# Altium schematic sheet DE-020224_01.SchDoc(DE-020224_01)
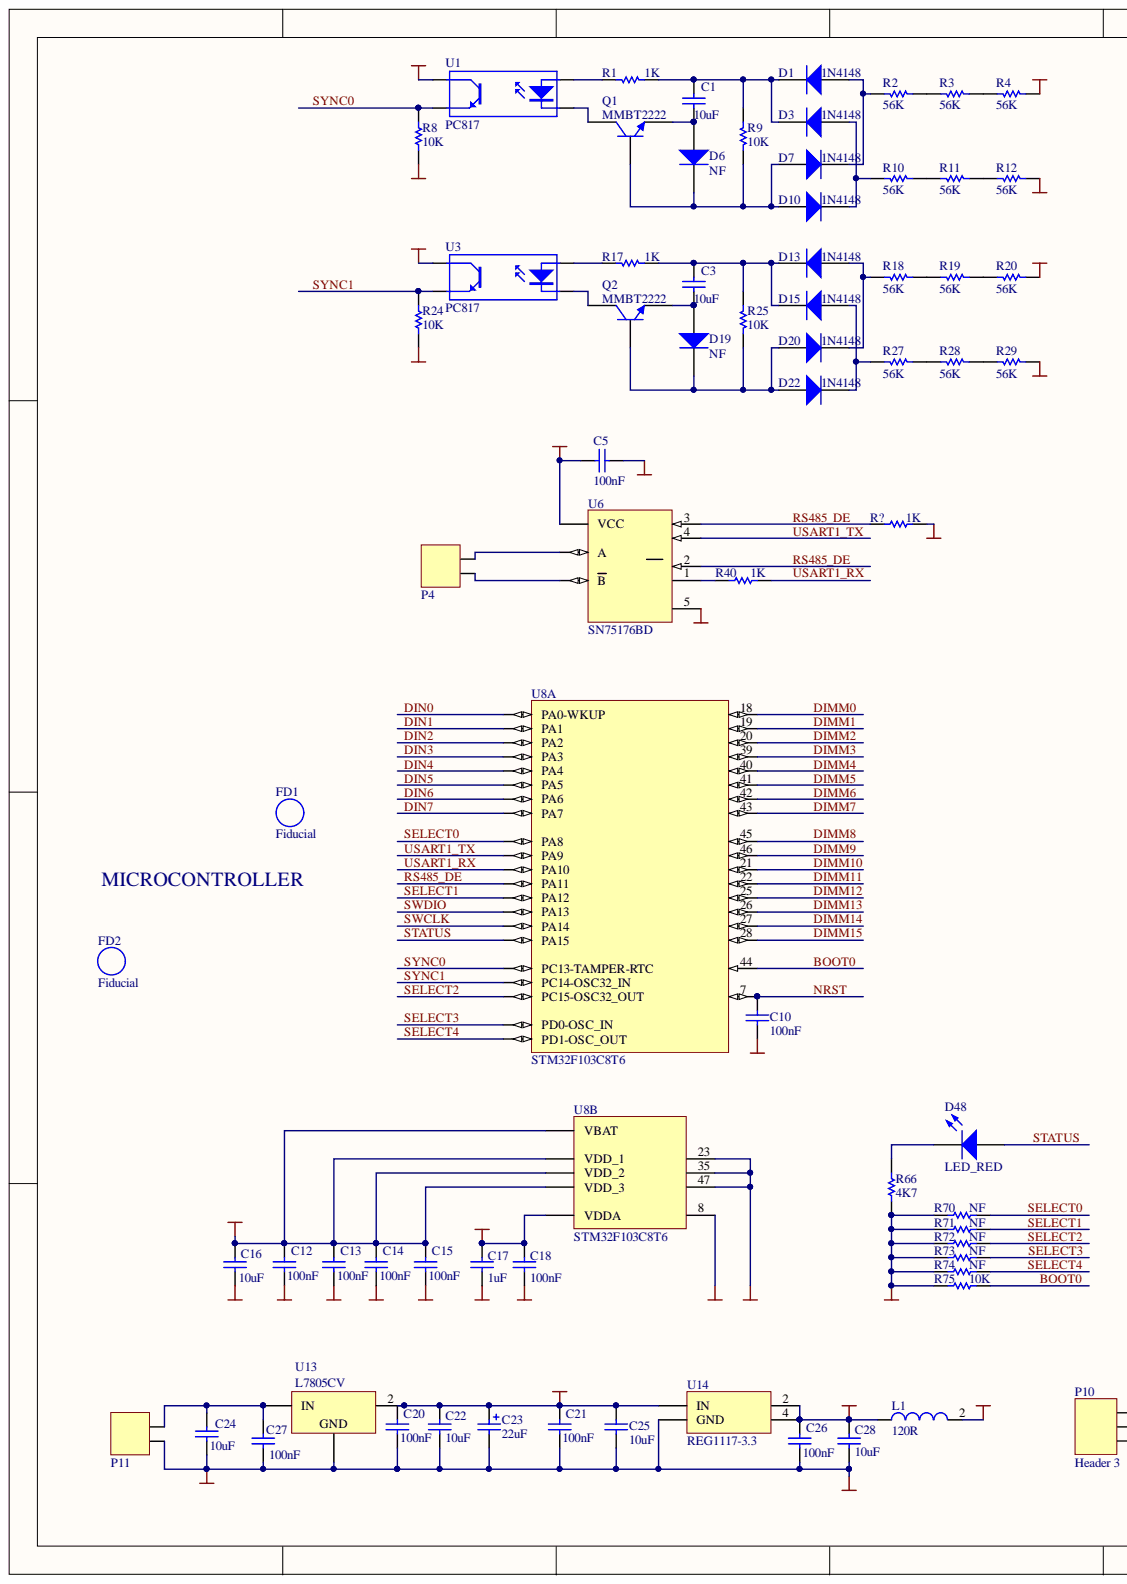
[diagram p0: DE-020224_01.SchDoc, left]
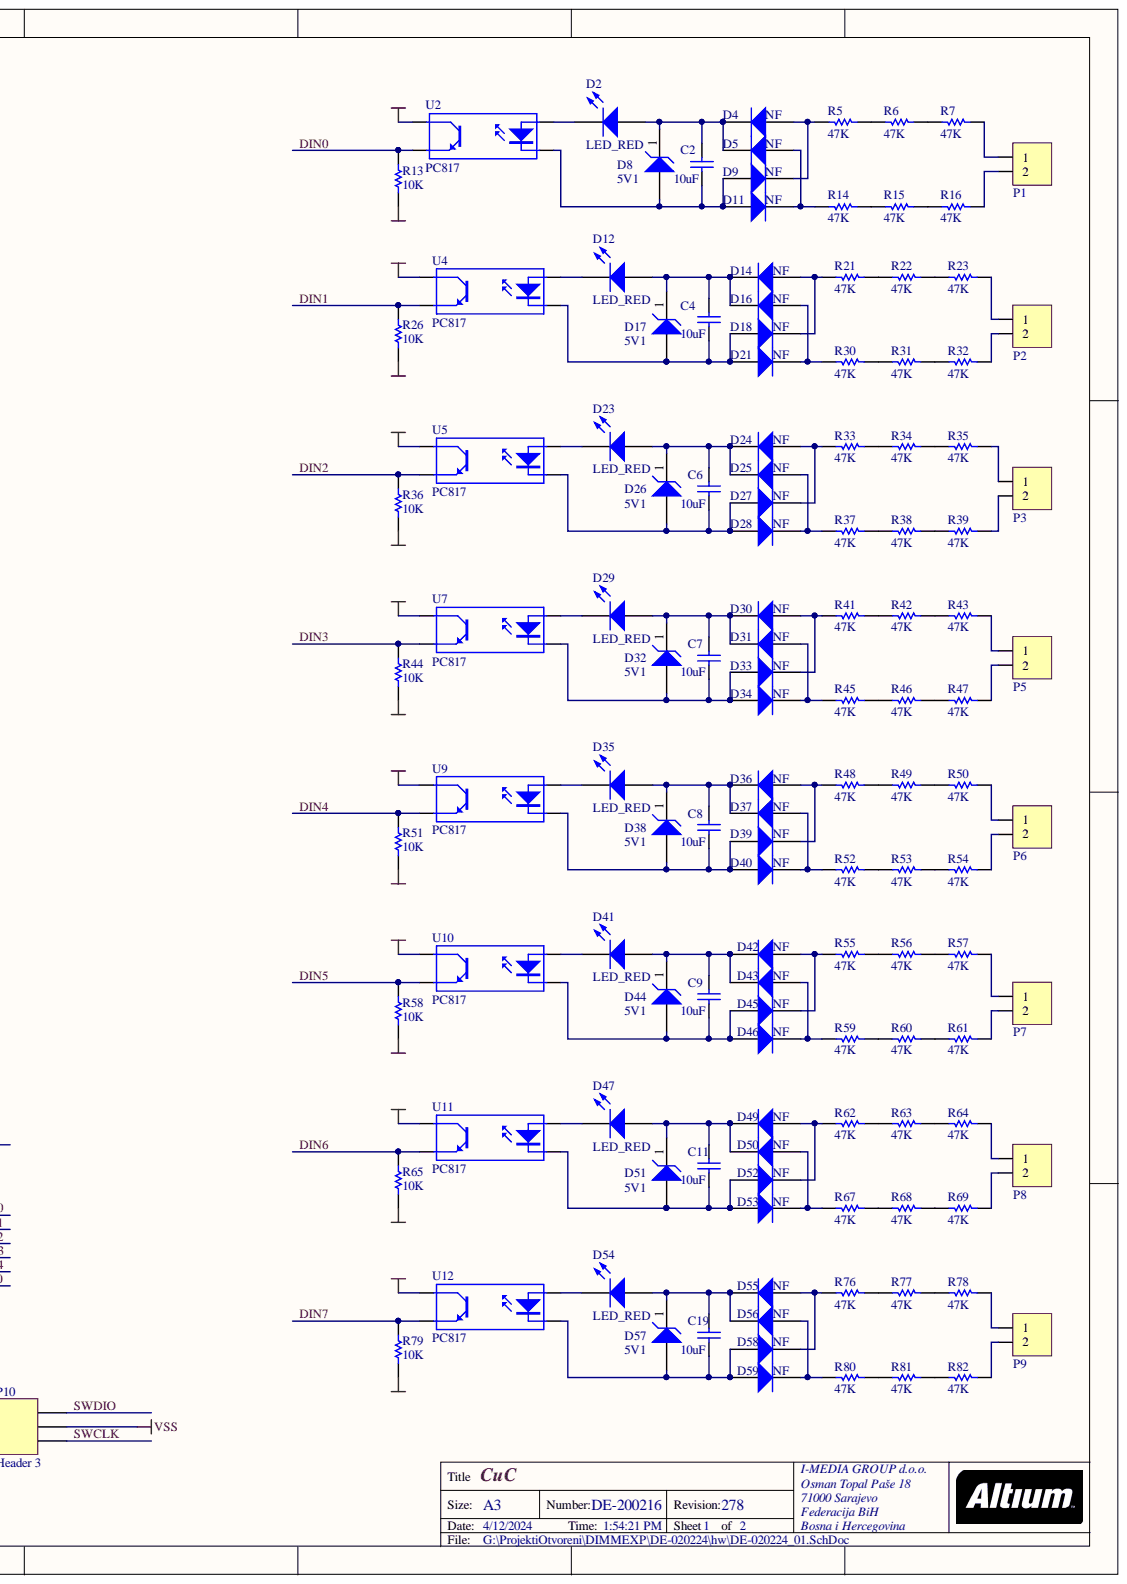
[diagram p1: DE-020224_01.SchDoc, right]

## Parts
C1  10uF  [0805]
C2  10uF  [0805]
C3  10uF  [0805]
C4  10uF  [0805]
C5  100nF  [0603]
C6  10uF  [0805]
C7  10uF  [0805]
C8  10uF  [0805]
C9  10uF  [0805]
C10  100nF  [0603]
C11  10uF  [0805]
C12  100nF  [0603]
C13  100nF  [0603]
C14  100nF  [0603]
C15  100nF  [0603]
C16  value 10uF  [0805]  (hidden comment: 4u7)
C17  1uF  [0603]
C18  100nF  [0603]
C19  10uF  [0805]
C20  100nF  [0603]
C21  100nF  [0603]
C22  10uF  [0805]
C23  22uF  [CAPPR2-5X11]
C24  10uF  [0805]
C25  10uF  [0805]
C26  100nF  [0603]
C27  100nF  [0603]
C28  10uF  [0805]
D1  1N4148  [SOD323]
D2  LED_RED  [1.6X0.8X0.8]
D3  1N4148  [SOD323]
D4  NF  [SOD323]
D5  NF  [SOD323]
D6  NF  [SOD323]
D7  1N4148  [SOD323]
D8  5V1  [ONSC-SOD-123-2-425-04_V]
D9  NF  [SOD323]
D10  1N4148  [SOD323]
D11  NF  [SOD323]
D12  LED_RED  [1.6X0.8X0.8]
D13  1N4148  [SOD323]
D14  NF  [SOD323]
D15  1N4148  [SOD323]
D16  NF  [SOD323]
D17  5V1  [ONSC-SOD-123-2-425-04_V]
D18  NF  [SOD323]
D19  NF  [SOD323]
D20  1N4148  [SOD323]
D21  NF  [SOD323]
D22  1N4148  [SOD323]
D23  LED_RED  [1.6X0.8X0.8]
D24  NF  [SOD323]
D25  NF  [SOD323]
D26  5V1  [ONSC-SOD-123-2-425-04_V]
D27  NF  [SOD323]
D28  NF  [SOD323]
D29  LED_RED  [1.6X0.8X0.8]
D30  NF  [SOD323]
D31  NF  [SOD323]
D32  5V1  [ONSC-SOD-123-2-425-04_V]
D33  NF  [SOD323]
D34  NF  [SOD323]
D35  LED_RED  [1.6X0.8X0.8]
D36  NF  [SOD323]
D37  NF  [SOD323]
D38  5V1  [ONSC-SOD-123-2-425-04_V]
D39  NF  [SOD323]
D40  NF  [SOD323]
D41  LED_RED  [1.6X0.8X0.8]
D42  NF  [SOD323]
D43  NF  [SOD323]
D44  5V1  [ONSC-SOD-123-2-425-04_V]
D45  NF  [SOD323]
D46  NF  [SOD323]
D47  LED_RED  [1.6X0.8X0.8]
D48  LED_RED  [1.6X0.8X0.8]
D49  NF  [SOD323]
D50  NF  [SOD323]
D51  5V1  [ONSC-SOD-123-2-425-04_V]
D52  NF  [SOD323]
D53  NF  [SOD323]
D54  LED_RED  [1.6X0.8X0.8]
D55  NF  [SOD323]
D56  NF  [SOD323]
D57  5V1  [ONSC-SOD-123-2-425-04_V]
D58  NF  [SOD323]
D59  NF  [SOD323]
FD1  Fiducial  [Fiducial]  (no BOM)
FD2  Fiducial  [Fiducial]  (no BOM)
L1  120R  [SMD-0805]
P1  TERMINAL BLOK 2  [TERMINAL BLOK 2]  (value not drawn)
P2  TERMINAL BLOK 2  [TERMINAL BLOK 2]  (value not drawn)
P3  TERMINAL BLOK 2  [TERMINAL BLOK 2]  (value not drawn)
P4  TERMINAL BLOK 2  [TERMINAL BLOK 2]  (value not drawn)
P5  TERMINAL BLOK 2  [TERMINAL BLOK 2]  (value not drawn)
P6  TERMINAL BLOK 2  [TERMINAL BLOK 2]  (value not drawn)
P7  TERMINAL BLOK 2  [TERMINAL BLOK 2]  (value not drawn)
P8  TERMINAL BLOK 2  [TERMINAL BLOK 2]  (value not drawn)
P9  TERMINAL BLOK 2  [TERMINAL BLOK 2]  (value not drawn)
P10  Header 3  [HDR1X3]
P11  TERMINAL BLOK 2  [TERMINAL BLOK 2]  (value not drawn)
Q1  MMBT2222  [SOT23_N]
Q2  MMBT2222  [SOT23_N]
R1  1K  [ERA-0805]
R2  56K  [ERA-0805]
R3  56K  [ERA-0805]
R4  56K  [ERA-0805]
R5  47K  [ERA-0805]
R6  47K  [ERA-0805]
R7  47K  [ERA-0805]
R8  10K  [ERA-0603]
R9  10K  [ERA-0805]
R10  56K  [ERA-0805]
R11  56K  [ERA-0805]
R12  56K  [ERA-0805]
R13  10K  [ERA-0603]
R14  47K  [ERA-0805]
R15  47K  [ERA-0805]
R16  47K  [ERA-0805]
R17  1K  [ERA-0805]
R18  56K  [ERA-0805]
R19  56K  [ERA-0805]
R20  56K  [ERA-0805]
R21  47K  [ERA-0805]
R22  47K  [ERA-0805]
R23  47K  [ERA-0805]
R24  10K  [ERA-0603]
R25  10K  [ERA-0805]
R26  10K  [ERA-0603]
R27  56K  [ERA-0805]
R28  56K  [ERA-0805]
R29  56K  [ERA-0805]
R30  47K  [ERA-0805]
R31  47K  [ERA-0805]
R32  47K  [ERA-0805]
R33  47K  [ERA-0805]
R34  47K  [ERA-0805]
R35  47K  [ERA-0805]
R36  10K  [ERA-0603]
R37  47K  [ERA-0805]
R38  47K  [ERA-0805]
R39  47K  [ERA-0805]
R40  1K  [ERA-0603]
R41  47K  [ERA-0805]
R42  47K  [ERA-0805]
R43  47K  [ERA-0805]
R44  10K  [ERA-0603]
R45  47K  [ERA-0805]
R46  47K  [ERA-0805]
R47  47K  [ERA-0805]
R48  47K  [ERA-0805]
R49  47K  [ERA-0805]
R50  47K  [ERA-0805]
R51  10K  [ERA-0603]
R52  47K  [ERA-0805]
R53  47K  [ERA-0805]
R54  47K  [ERA-0805]
R55  47K  [ERA-0805]
R56  47K  [ERA-0805]
R57  47K  [ERA-0805]
R58  10K  [ERA-0603]
R59  47K  [ERA-0805]
R60  47K  [ERA-0805]
R61  47K  [ERA-0805]
R62  47K  [ERA-0805]
R63  47K  [ERA-0805]
R64  47K  [ERA-0805]
R65  10K  [ERA-0603]
R66  4K7  [ERA-0603]
R67  47K  [ERA-0805]
R68  47K  [ERA-0805]
R69  47K  [ERA-0805]
R70  NF  [ERA-0603]
R71  NF  [ERA-0603]
R72  NF  [ERA-0603]
R73  NF  [ERA-0603]
R74  NF  [ERA-0603]
R75  10K  [ERA-0603]
R76  47K  [ERA-0805]
R77  47K  [ERA-0805]
R78  47K  [ERA-0805]
R79  10K  [ERA-0603]
R80  47K  [ERA-0805]
R81  47K  [ERA-0805]
R82  47K  [ERA-0805]
R?  1K  [ERA-0603]
U1  PC817  [DIP-4]
U2  PC817  [DIP-4]
U3  PC817  [DIP-4]
U4  PC817  [DIP-4]
U5  PC817  [DIP-4]
U6  SN75176BD  [D008_L]
U7  PC817  [DIP-4]
U8  STM32F103C8T6  [STM-LQFP48_N]  (parts A,B)
U9  PC817  [DIP-4]
U10  PC817  [DIP-4]
U11  PC817  [DIP-4]
U12  PC817  [DIP-4]
U13  L7805CV  [TO220ABN]
U14  REG1117-3.3  [ZZ311]

## Nets
+3V3: C12.2 C13.2 C14.2 C15.2 C16.2 C26.2 C28.2 L1.1 U1.4 U2.4 U3.4 U4.4 U5.4 U7.4 U8.1(VBAT) U8.24(VDD_1) U8.36(VDD_2) U8.48(VDD_3) U9.4 U10.4 U11.4 U12.4 U14.2 U14.4
+5V: C5.1 C20.2 C21.2 C22.2 C23.1 C25.2 U6.8(VCC) U13.2 U14.3(IN)
L1: R4.1
L2: R20.1
N1: R12.1
N2: R29.1
VDDA: C17.2 C18.2 L1.2 U8.9(VDDA)
VSS: C5.2 C10.1 C12.1 C13.1 C14.1 C15.1 C16.1 C17.1 C18.1 C20.1 C21.1 C22.1 C23.2 C24.1 C25.1 C26.1 C27.1 C28.1 P10.2 P11.1 R8.2 R13.2 R24.2 R26.2 R36.2 R44.2 R51.2 R58.2 R65.2 R66.2 R70.2 R71.2 R72.2 R73.2 R74.2 R75.2 R79.2 R?.2 U6.5 U8.8 U8.23 U8.35 U8.47 U13.3 U14.1(GND)
BOOT0: R75.1 U8.44
DIMM0: U8.18
DIMM1: U8.19
DIMM2: U8.20
DIMM3: U8.39
DIMM4: U8.40
DIMM5: U8.41
DIMM6: U8.42
DIMM7: U8.43
DIMM8: U8.45
DIMM9: U8.46
DIMM10: U8.21
DIMM11: U8.22
DIMM12: U8.25
DIMM13: U8.26
DIMM14: U8.27
DIMM15: U8.28
DIN0: R13.1 U2.3 U8.10(PA0-WKUP)
DIN1: R26.1 U4.3 U8.11(PA1)
DIN2: R36.1 U5.3 U8.12(PA2)
DIN3: R44.1 U7.3 U8.13(PA3)
DIN4: R51.1 U8.14(PA4) U9.3
DIN5: R58.1 U8.15(PA5) U10.3
DIN6: R65.1 U8.16(PA6) U11.3
DIN7: R79.1 U8.17(PA7) U12.3
NRST: C10.2 U8.7
RS485_DE: R?.1 U6.2 U6.3 U8.32(PA11)
SELECT0: R70.1 U8.29(PA8)
SELECT1: R71.1 U8.33(PA12)
SELECT2: R72.1 U8.4(PC15-OSC32_OUT)
SELECT3: R73.1 U8.5(PD0-OSC_IN)
SELECT4: R74.1 U8.6(PD1-OSC_OUT)
STATUS: D48.1 U8.38(PA15)
SWCLK: P10.1 U8.37(PA14)
SWDIO: P10.3 U8.34(PA13)
SYNC0: R8.1 U1.3 U8.2(PC13-TAMPER-RTC)
SYNC1: R24.1 U3.3 U8.3(PC14-OSC32_IN)
USART1_RX: R40.2 U8.31(PA10)
USART1_TX: U6.4 U8.30(PA9)
NetC1_1: C1.1 D6.2 Q1.2
NetC1_2: C1.2 D1.1 D3.1 R1.2 R9.1
NetC2_1: C2.1 D8.2 D9.2 D11.2 U2.2
NetC2_2: C2.2 D2.1 D4.1 D5.1 D8.1
NetC3_1: C3.1 D19.2 Q2.2
NetC3_2: C3.2 D13.1 D15.1 R17.2 R25.1
NetC4_1: C4.1 D17.2 D18.2 D21.2 U4.2
NetC4_2: C4.2 D12.1 D14.1 D16.1 D17.1
NetC6_1: C6.1 D26.2 D27.2 D28.2 U5.2
NetC6_2: C6.2 D23.1 D24.1 D25.1 D26.1
NetC7_1: C7.1 D32.2 D33.2 D34.2 U7.2
NetC7_2: C7.2 D29.1 D30.1 D31.1 D32.1
NetC8_1: C8.1 D38.2 D39.2 D40.2 U9.2
NetC8_2: C8.2 D35.1 D36.1 D37.1 D38.1
NetC9_1: C9.1 D44.2 D45.2 D46.2 U10.2
NetC9_2: C9.2 D41.1 D42.1 D43.1 D44.1
NetC11_1: C11.1 D51.2 D52.2 D53.2 U11.2
NetC11_2: C11.2 D47.1 D49.1 D50.1 D51.1
NetC19_1: C19.1 D57.2 D58.2 D59.2 U12.2
NetC19_2: C19.2 D54.1 D55.1 D56.1 D57.1
NetC24_2: C24.2 C27.2 P11.2 U13.1
NetD1_2: D1.2 D7.1 R2.2
NetD2_2: D2.2 U2.1
NetD3_2: D3.2 D10.1 R10.2
NetD4_2: D4.2 D9.1 R5.2
NetD5_2: D5.2 D11.1 R14.2
NetD6_1: D6.1 D7.2 D10.2 Q1.1 R9.2
NetD12_2: D12.2 U4.1
NetD13_2: D13.2 D20.1 R18.2
NetD14_2: D14.2 D18.1 R21.2
NetD15_2: D15.2 D22.1 R27.2
NetD16_2: D16.2 D21.1 R30.2
NetD19_1: D19.1 D20.2 D22.2 Q2.1 R25.2
NetD23_2: D23.2 U5.1
NetD24_2: D24.2 D27.1 R33.2
NetD25_2: D25.2 D28.1 R37.2
NetD29_2: D29.2 U7.1
NetD30_2: D30.2 D33.1 R41.2
NetD31_2: D31.2 D34.1 R45.2
NetD35_2: D35.2 U9.1
NetD36_2: D36.2 D39.1 R48.2
NetD37_2: D37.2 D40.1 R52.2
NetD41_2: D41.2 U10.1
NetD42_2: D42.2 D45.1 R55.2
NetD43_2: D43.2 D46.1 R59.2
NetD47_2: D47.2 U11.1
NetD48_2: D48.2 R66.1
NetD49_2: D49.2 D52.1 R62.2
NetD50_2: D50.2 D53.1 R67.2
NetD54_2: D54.2 U12.1
NetD55_2: D55.2 D58.1 R76.2
NetD56_2: D56.2 D59.1 R80.2
NetP1_1: P1.1 R7.1
NetP1_2: P1.2 R16.1
NetP2_1: P2.1 R23.1
NetP2_2: P2.2 R32.1
NetP3_1: P3.1 R35.1
NetP3_2: P3.2 R39.1
NetP4_1: P4.1 U6.7(B)
NetP4_2: P4.2 U6.6(A)
NetP5_1: P5.1 R43.1
NetP5_2: P5.2 R47.1
NetP6_1: P6.1 R50.1
NetP6_2: P6.2 R54.1
NetP7_1: P7.1 R57.1
NetP7_2: P7.2 R61.1
NetP8_1: P8.1 R64.1
NetP8_2: P8.2 R69.1
NetP9_1: P9.1 R78.1
NetP9_2: P9.2 R82.1
NetQ1_3: Q1.3 U1.2
NetQ2_3: Q2.3 U3.2
NetR1_1: R1.1 U1.1
NetR2_1: R2.1 R3.2
NetR3_1: R3.1 R4.2
NetR5_1: R5.1 R6.2
NetR6_1: R6.1 R7.2
NetR10_1: R10.1 R11.2
NetR11_1: R11.1 R12.2
NetR14_1: R14.1 R15.2
NetR15_1: R15.1 R16.2
NetR17_1: R17.1 U3.1
NetR18_1: R18.1 R19.2
NetR19_1: R19.1 R20.2
NetR21_1: R21.1 R22.2
NetR22_1: R22.1 R23.2
NetR27_1: R27.1 R28.2
NetR28_1: R28.1 R29.2
NetR30_1: R30.1 R31.2
NetR31_1: R31.1 R32.2
NetR33_1: R33.1 R34.2
NetR34_1: R34.1 R35.2
NetR37_1: R37.1 R38.2
NetR38_1: R38.1 R39.2
NetR40_1: R40.1 U6.1
NetR41_1: R41.1 R42.2
NetR42_1: R42.1 R43.2
NetR45_1: R45.1 R46.2
NetR46_1: R46.1 R47.2
NetR48_1: R48.1 R49.2
NetR49_1: R49.1 R50.2
NetR52_1: R52.1 R53.2
NetR53_1: R53.1 R54.2
NetR55_1: R55.1 R56.2
NetR56_1: R56.1 R57.2
NetR59_1: R59.1 R60.2
NetR60_1: R60.1 R61.2
NetR62_1: R62.1 R63.2
NetR63_1: R63.1 R64.2
NetR67_1: R67.1 R68.2
NetR68_1: R68.1 R69.2
NetR76_1: R76.1 R77.2
NetR77_1: R77.1 R78.2
NetR80_1: R80.1 R81.2
NetR81_1: R81.1 R82.2

## Library data of the parts
C1-C4 C6-C9 C11 C16 C19 C22 C24 C25 C28 = C0805: Ceramic Chip Capacitor - Standard; Note: Footprint corresponds to dimensions for bulk cassette packaging. This case size has tightened tolerances.; Simulation: CAP; Signal Integrity: C0805; Published: 17-Jul-2002; PackageReference: 0805; PackageDocument: Mar-2001; LatestRevisionNote: Silkscreen size reduced.; LatestRevisionDate: 19-Mar-2004; DatasheetDocument: Mar-2001; ComponentLink2URL: http://www.kemet.com/kemet/web/homepage/kechome.nsf/vapubfilesname/F3102TA.pdf/$file/F3102TA.pdf; ComponentLink2Description: Datasheet; ComponentLink1URL: http://www.kemet.com/; ComponentLink1Description: Manufacturer Link; Component Kind: Standard; Code_JEITA: 0805; Code_IPC: 2012[0805]; Publisher: Altium Limited
  C1-C4 C6-C9 C11 C19: Library Name: DE-020224.SchLib
  C16 C22 C28: Library Name: DE-251215.SchLib
  C24 C25: Library Name: DE-200216_03.SchLib
C5 C10 C12-C15 C17 C18 C20 C21 C26 C27 = C0603: Ceramic Chip Capacitor - Standard; Note: Footprint corresponds to dimensions for bulk cassette packaging. This case size has tightened tolerances.; Simulation: CAP; Signal Integrity: C0603; Published: 17-Jul-2002; PackageReference: 0603; PackageDocument: Mar-2001; LatestRevisionNote: Silkscreen size reduced.; LatestRevisionDate: 19-Mar-2004; DatasheetDocument: Mar-2001; ComponentLink2URL: http://www.kemet.com/kemet/web/homepage/kechome.nsf/vapubfilesname/F3102TA.pdf/$file/F3102TA.pdf; ComponentLink2Description: Datasheet; ComponentLink1URL: http://www.kemet.com/; ComponentLink1Description: Manufacturer Link; Component Kind: Standard; Code_JEITA: 0603; Code_IPC: 1608 [0603]; Publisher: Altium Limited
  C5: Library Name: DE-020224.SchLib
  C10: Value: 100nF; Library Name: DE-010116.SchLib
  C12-C15 C20 C21 C26: Library Name: DE-251215.SchLib
  C17: Value: 1uF; Library Name: DE-200216_01.SchLib
  C18: Value: 100nF; Library Name: DE-200216_01.SchLib
  C27: Value: 100nF; Library Name: DE-251215.SchLib
C23 = EKA00AA247F00: Aluminum Electrolytic Capacitor, Radial Style with Long Leads: 47uF, 35V; Note: Refer to Manufacturer's Data Sheets for other lead formations; DrillSize: Nominal, Rounded To The Nearest 0.1mm; Value: 47uF; Simulation: CAP; Signal Integrity: EKA00AA247F00; Published: 19-Mar-2004; PackageReference: CAPPR2-5X11; Library Name: DE-020224.SchLib; DatasheetDocument: 20-Feb-2001; ComponentLink2URL: http://www.vishay.com/docs/25014/eka.pdf; ComponentLink2Description: Datasheet; ComponentLink1URL: http://www.vishay.com/; ComponentLink1Description: Manufacturer Link; Component Kind: Standard; Publisher: Altium Limited
D1 D3-D7 D9-D11 D13-D16 D18-D22 D24 D25 D27 D28 D30 D31 D33 D34 D36 D37 D39 D40 D42 D43 D45 D46 D49 D50 D52 D53 D55 D56 D58 D59 = BAS321: General-purpose Diode; Simulation: BAS321; Signal Integrity: BAS321; Published: 17-Sep-2003; PackageVersion: May-1999; PackageReference: SOD323; PackageDescription: SOD-323/SC-76; 2 Leads; Body 1.7 x 1.3 mm, (LxW); Library Name: DE-020224.SchLib; LatestRevisionNote: Silkscreen size reduced.; LatestRevisionDate: 19-Mar-2004; DatasheetVersion: 9-Feb-1999; ComponentLink2URL: http://www.semiconductors.philips.com/acrobat/datasheets/BAS321_2.pdf; ComponentLink2Description: Datasheet; ComponentLink1URL: http://www.semiconductors.philips.com/; ComponentLink1Description: Manufacturer Link; Component Kind: Standard; Publisher: Altium Limited
D2 D12 D23 D29 D35 D41 D47 D48 D54 = HSMZ-C190: High Performance Chip LED, Red; PCB_Layout: Complies with manufacturer's recommendation.; LuminousIntensity: 40mcd (Minimum).; Simulation: Agl_HSMZC110; Signal Integrity: LED; Published: 11-Dec-2002; PackageReference: 1.6X0.8X0.8; PackageDocument: 11-Mar-2002; Library Name: DE-020224.SchLib; LED_Colour: Red; DatasheetDocument: 11-Mar-2002; ComponentLink2URL: http://cp.literature.agilent.com/litweb/pdf/5988-5891EN.pdf; ComponentLink2Description: Datasheet; ComponentLink1URL: http://www.agilent.com/; ComponentLink1Description: Manufacturer Link; Component Kind: Standard; Publisher: Altium Limited
D8 D17 D26 D32 D38 D44 D51 D57 = MMSZ5V1T1: Zener Voltage Regulator, 500 mW, 2-Pin SOD-123, Tape and Reel; Manufacturer: On Semiconductor; P Max (W): 0.5; Supplier Part Number 2: 863-MMSZ5V1T1; Supplier Part Number 1: MMSZ5V1T1OSCT-ND; Supplier 2: Mouser; Supplier 1: Digi-Key; PartNumber: MMSZ5V1T1; Packing: Tape and Reel; PackageVersion: Rev. G, 10/2009; PackageReference: SOD-123-2-425-04; PackageDescription: 2-Pin SOD, Body 1.6 x 2.69 mm; Mounting Technology: Surface Mount; Library Name: ON Semi Zener Diodes.IntLib; DatasheetVersion: Rev. 11; ComponentLink3URL: http://www.onsemi.com/pub_link/Collateral/425-04.PDF; ComponentLink3Description: Package Specification; ComponentLink2URL: http://www.onsemi.com/pub_link/Collateral/MMSZ2V4T1-D.PDF; ComponentLink2Description: Datasheet; ComponentLink1URL: http://www.onsemi.com/; ComponentLink1Description: Manufacturer URL; Component Kind: Standard
FD1 FD2 = Fiduchial: Fiduchial mark; Library Name: DE-200216_02_1.SCHLIB
L1 = 74279202: SMD EMI Suppression Ferrite Bead WE-CBF, Z = 120 Ohm; Impedance: 120 Ohm; PackageVersion: 2005; PackageReference: SMD-0805; PackageDescription: Chip Inductor, 2-Leads, Body 2.0 x 1.2 mm; Library Name: Wuerth Elektronik EMC Ferrite.IntLib; DatasheetVersion: Dec-2005; ComponentLink2URL: http://www.we-online.de/katalog/media/pdf/74279202.pdf; ComponentLink2Description: Datasheet; ComponentLink1URL: http://www.we-online.com; ComponentLink1Description: Manufacturer URL; Component Kind: Standard
P1-P9 P11 = TERMINAL BLOK 2: 2 pinski terminal blok; Library Name: DE-020224.SchLib; Component Kind: Standard
P10 = Header 3: Header, 3-Pin; Signal Integrity: Connector; Library Name: Miscellaneous Connectors.IntLib; LatestRevisionNote: Re-released for DXP Platform.; LatestRevisionDate: 17-Jul-2002; Component Kind: Standard; Publisher: Altium Limited
Q1 Q2 = BC817: NPN General-purpose Transistor; Simulation: QBC817; Signal Integrity: BC817; Published: 17-Sep-2003; PackageVersion: May-1999; PackageReference: SOT23; PackageDescription: 3-Pin SOT-23 Package 0.95 mm Pitch, 2.3 mm Lead Span; Library Name: DE-020224.SchLib; LatestRevisionNote: IPC-7351 Footprint Added.; LatestRevisionDate: 12-Dec-2006; DatasheetVersion: Jun-1999; ComponentLink2URL: http://www.semiconductors.philips.com/acrobat/datasheets/BC817_BC817W_BC337_5.pdf; ComponentLink2Description: Datasheet; ComponentLink1URL: http://www.semiconductors.philips.com/; ComponentLink1Description: Manufacturer Link; Component Kind: Standard; Publisher: Altium Limited
R1-R7 R9-R12 R14-R23 R25 R27-R35 R37-R39 R41-R43 R45-R50 R52-R57 R59-R64 R67-R69 R76-R78 R80-R82 = ERA6Y: Rectangular Thick Film Chip Resistor, 10 Ohm to 1M Ohm Range, 0.1% and 0.5% Tolerance, 0805 Size, 0.1 W; Note: If flow soldering, adjust pad width of PCB Footprint to 0.7 - 0.8 times the width of the resistor.; Simulation: RESISTOR; Signal Integrity: Res; Published: 17-Dec-2003; PackageReference: ERA-0805; PackageDocument: Mar-2003; Library Name: DE-020224.SchLib; LatestRevisionNote: Silkscreen size reduced.; LatestRevisionDate: 19-Mar-2004; ComponentLink2URL: http://www.panasonic.com/industrial/components/pdf/AOA0000CE8.pdf; ComponentLink2Description: Datasheet; ComponentLink1URL: http://www.panasonic.com/industrial/components/resistive_products.htm; ComponentLink1Description: Manufacturer Link; Component Kind: Standard; Code_IPC: 2012 [0805]; Publisher: Altium Limited
R8 R13 R24 R26 R36 R40 R44 R51 R58 R65 R66 R70-R75 R79 R? = ERAV: Metal Film Thermosensitive Chip Resistor, 0.56 Ohm to 10k Ohm Range, 5% Tolerance, 0603 Size, 0.063 W; Note: If flow soldering, adjust pad width of PCB Footprint to 0.7 - 0.8 times the width of the resistor.; Simulation: RESISTOR; Signal Integrity: Res; Published: 17-Dec-2003; PackageReference: ERA-0603; PackageDocument: Mar-2003; Library Name: DE-010116.SchLib; LatestRevisionNote: Silkscreen size reduced.; LatestRevisionDate: 19-Mar-2004; ComponentLink2URL: http://www.panasonic.com/industrial/components/pdf/AOF0000CE1.pdf; ComponentLink2Description: Datasheet; ComponentLink1URL: http://www.panasonic.com/industrial/components/resistive_products.htm; ComponentLink1Description: Manufacturer Link; Component Kind: Standard; Code_IPC: 1608 [0603]; Publisher: Altium Limited
U1-U5 U7 U9-U12 = PC817: 4-Pin Phototransistor Optocoupler; Published: 24-Mar-1999; PackageReference: DIP-4; Library Name: DE-020224.SchLib; LatestRevisionNote: Sch pins 3 & 4 Swapped.; LatestRevisionDate: 04-Mar-2005; DatasheetVersion: Nov-2003; Component Kind: Standard; Publisher: Altium Limited
U6 = SN75176BD: Differential Bus Transceiver; Note: Receiver Hysteresis of 50mV Typical; Published: 15-May-2002; PCB3D: D008; PackageVersion: Jan-1998; PackageReference: D008; PackageDescription: 8-Pin Small Outline Integrated Circuit 1.27 mm Pitch; Library Name: DE-020224.SchLib; LatestRevisionNote: IPC-7351 Footprint Added.; LatestRevisionDate: 13-Apr-2006; DatasheetVersion: Sep-1989; ComponentLink2URL: http://www-s.ti.com/sc/ds/sn75176b.pdf; ComponentLink2Description: Datasheet; ComponentLink1URL: http://www.ti.com/; ComponentLink1Description: Manufacturer Link; Component Kind: Standard; Code_IPC: SOIC127P600-8; Publisher: Altium Limited
U8 = STM32F103C8T6: ARM Cortex-M3 32-bit MCU, 64 KB Flash, 20 KB Internal RAM, 37 I/Os, 48-pin LQFP, -40 to 85 degC, Tray; Supply Voltage(Vcc) min (V): 2; Operating Frequency(F) (Processor speed) (MHz): 72; Internal RAM Size (kB): 20; I/Os (High Current): 37; FLASH Size (Prog) (kB): 64; A/D Converter: 2x12-bit (10 Channel); Supplier Part Number 5: 511-STM32F103C8T6; Supplier Part Number 4: 57P1222; Supplier Part Number 3: 59M2182; Supplier Part Number 2: 1447637; Supplier Part Number 1: 497-6063-ND; Supplier 5: Mouser; Supplier 4: Newark; Supplier 3: Newark; Supplier 2: Farnell; Supplier 1: Digi-Key; PartNumber: STM32F103C8T6; Packing: Tray; PackageVersion: Rev. 6, 06/2013; PackageReference: LQFP48; PackageDescription: 48-Pin Low Profile Quad Flat Package, 7 x 7 mm Body, 0.5 mm Pitch; Mounting Technology: Surface Mount; Library Name: STMicroelectronics STM32 F1.IntLib; DatasheetVersion: Rev. 16; Core: ARM Cortex-M3; ComponentLink3URL: http://www.st.com/st-web-ui/static/active/en/resource/technical/document/datasheet/DM00058181.pdf; ComponentLink3Description: Package Specification; ComponentLink2URL: http://www.st.com/st-web-ui/static/active/en/resource/technical/document/datasheet/CD00161566.pdf; ComponentLink2Description: Datasheet; ComponentLink1URL: http://www.st.com/web/en/home.html; ComponentLink1Description: Manufacturer URL; Component Kind: Standard
U13 = L7805CV: Positive Voltage Regulator; Note: Tab is connected to pin 3 (GND).; Published: 24-Aug-2001; PackageVersion: Jun-1998; PackageReference: TO220ABN; PackageDescription: TO, Thru-Hole, Vertical, Heatsink Mounted; 3 In-Line Leads; Pitch 2.54 mm; Pin sequence 1, 3, 2; Library Name: ST Power Mgt Voltage Regulator.IntLib; LatestRevisionNote: Re-released for DXP Platform.; LatestRevisionDate: 17-Jul-2002; DatasheetVersion: Dec-1998; ComponentLink1URL: http://www.st.com/; ComponentLink1Description: Manufacturer Link; Component Kind: Standard; Publisher: Altium Limited
U14 = REG1117-3.3: 800mA and 1A Low Dropout Positive Regulator 2.85V, 3.3V, 5V, and Adjustable; Published: 8-Jun-2000; PackageVersion: revC; PackageReference: ZZ311; PackageDescription: SOT-223/SC-73; 4 Leads; Body 6.7 x 7.3 mm, inc. leads (LxW max); Library Name: DE-251215.SchLib; LatestRevisionNote: Re-released for DXP Platform.; LatestRevisionDate: 17-Jul-2002; DatasheetVersion: Sep-1997; ComponentLink2URL: http://www-s.ti.com/sc/ds/REG1117.pdf; ComponentLink2Description: Datasheet; ComponentLink1URL: http://www.ti.com/; ComponentLink1Description: Manufacturer Link; Component Kind: Standard; Publisher: Altium Limited
